# Altium schematic sheet Power_Indicator.SchDoc
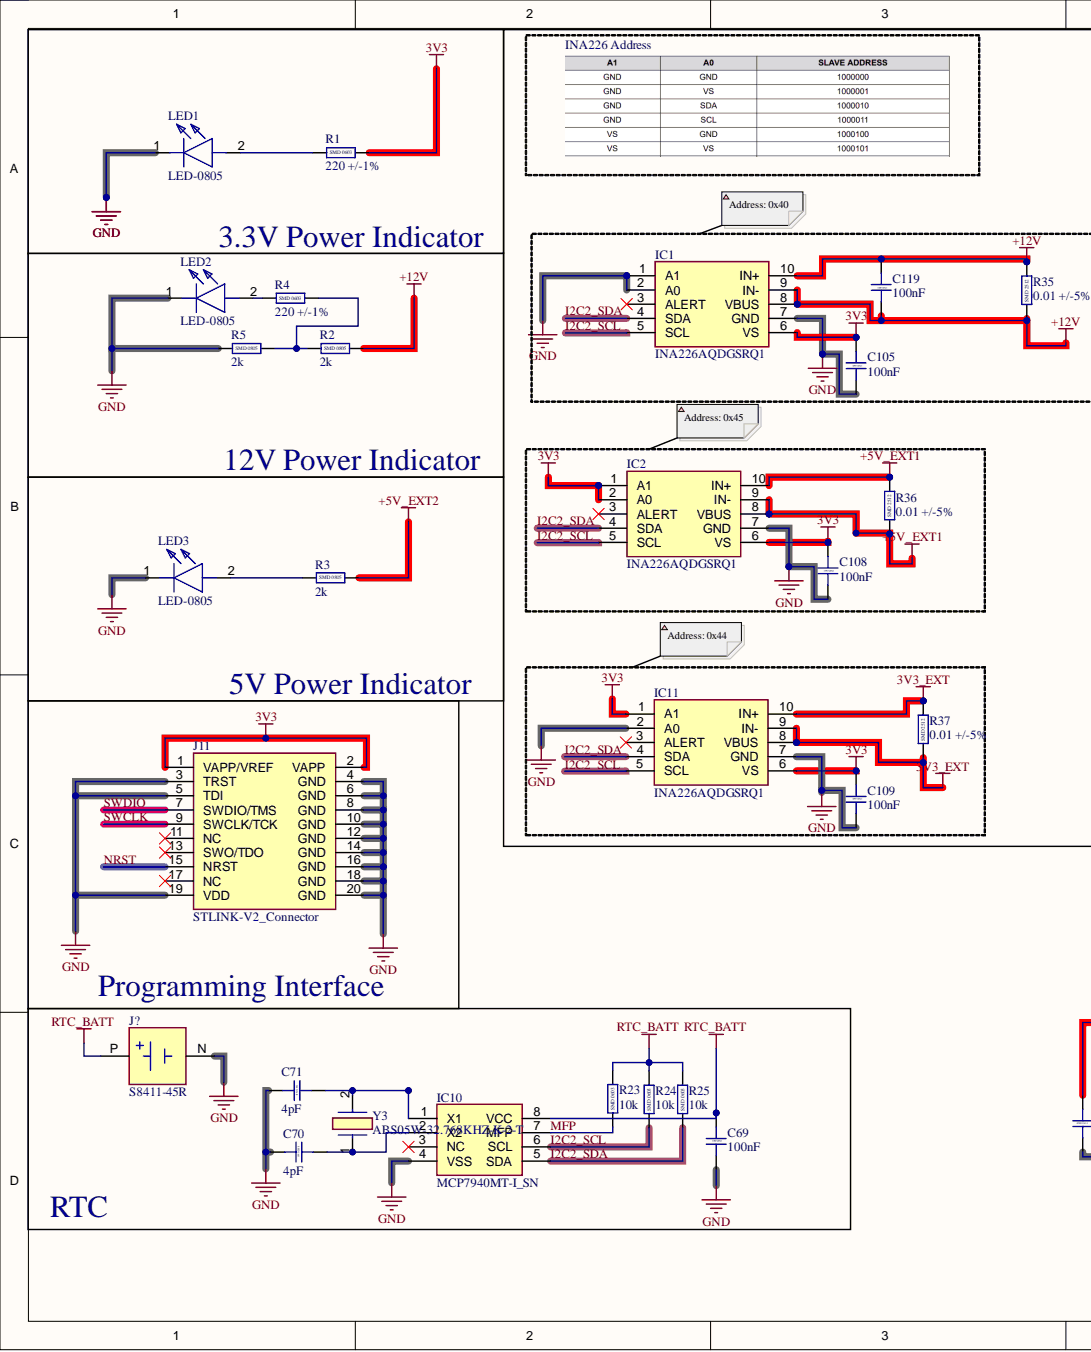
[diagram p0: Power_Indicator.SchDoc, left]
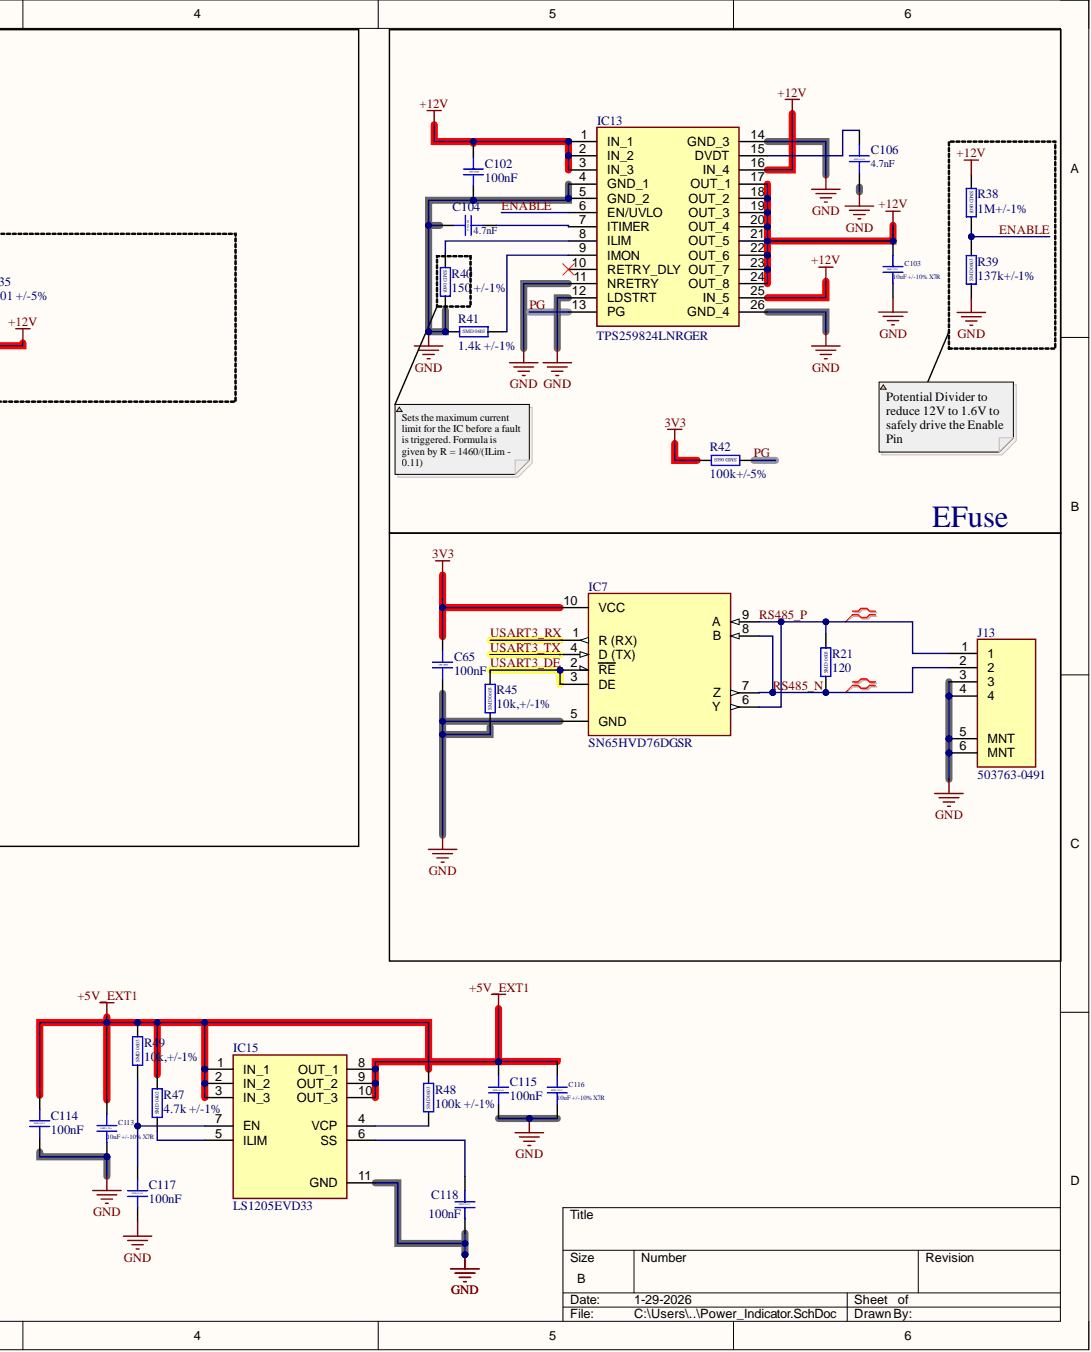
[diagram p1: Power_Indicator.SchDoc, right]

## Parts
C65  100nF  [CAP_0603]
C69  100nF  [CAP_0603]
C70  4pF  [CAP_0603]
C71  4pF  [CAP_0603]
C102  100nF  [CAP_0603]
C103  10uF +/-10% X7R  [CAP_1206]
C104  4.7nF  [CAP_0603]
C105  100nF  [CAP_0603]
C106  4.7nF  [CAP_0603]
C108  100nF  [CAP_0603]
C109  100nF  [CAP_0603]
C113  10uF +/-10% X7R  [CAP_1206]
C114  100nF  [CAP_0603]
C115  100nF  [CAP_0603]
C116  10uF +/-10% X7R  [CAP_1206]
C117  100nF  [CAP_0603]
C118  100nF  [CAP_0603]
C119  100nF  [CAP_0603]
IC1  INA226AQDGSRQ1  [SOP50P490X110-10N]
IC2  INA226AQDGSRQ1  [SOP50P490X110-10N]
IC7  SN65HVD76DGSR  [SOP50P490X110-10N]
IC10  MCP7940MT-I_SN  [SOIC127P600X175-8N]
IC11  INA226AQDGSRQ1  [SOP50P490X110-10N]
IC13  TPS259824LNRGER  [TPS259824LNRGER]
IC15  LS1205EVD33  [LS1205EVD33]
J11  STLINK-V2_Connector  [SHDR20W64P254_2X10_3272X859X991P]
J13  503763-0491  [5037630491]
J?  S8411-45R  [HARWIN_S8411-45R]
LED1  LED-0805  [LEDC3216X120N]
LED2  LED-0805  [LEDC3216X120N]
LED3  LED-0805  [LEDC3216X120N]
R1  220 +/-1%  [RES_0603]
R2  2k  [RES_0805]
R3  2k  [RES_0805]
R4  220 +/-1%  [RES_0603]
R5  2k  [RES_0805]
R21  120  [RES_0603]
R23  10k  [RES_0603]
R24  10k  [RES_0603]
R25  10k  [RES_0603]
R35  0.01 +/-5%  [RES_2512]
R36  0.01 +/-5%  [RES_2512]
R37  0.01 +/-5%  [RES_2512]
R38  1M+/-1%  [RES_0805]
R39  137k+/-1%  [RES_0603]
R40  150 +/-1%  [RES_0603]
R41  1.4k +/-1%  [RES_0603]
R42  100k+/-5%  [RES_0603]
R45  10k,+/-1%  [RES_0603]
R47  4.7k +/-1%  [RES_0402]
R48  100k +/-1%  [RES_0603]
R49  10k,+/-1%  [RES_0603]
Y3  ABS05W-32.768KHZ-K-2-T  [ABS05]

## Nets
3V3: C65.2 C105.2 C108.2 C109.2 IC1.6(VS) IC2.1(A1) IC2.2(A0) IC2.6(VS) IC7.10(VCC) IC11.1(A1) IC11.6(VS) J11.1(VAPP/VREF) J11.2(VAPP) R1.2 R42.2
3V3_EXT: IC11.8(VBUS) IC11.9(IN-) IC11.10(IN+) R37.1 R37.2
+5V_EXT1: C113.1 C114.1 C115.1 C116.1 IC2.8(VBUS) IC2.9(IN-) IC2.10(IN+) IC15.1(IN_1) IC15.2(IN_2) IC15.3(IN_3) IC15.8(OUT_1) IC15.9(OUT_2) IC15.10(OUT_3) R36.1 R36.2 R47.2 R48.2 R49.2
+5V_EXT2: R3.2
+12V: C102.2 C103.1 C119.1 C119.2 IC1.8(VBUS) IC1.9(IN-) IC1.10(IN+) IC13.1(IN_1) IC13.2(IN_2) IC13.3(IN_3) IC13.16(IN_4) IC13.17(OUT_1) IC13.18(OUT_2) IC13.19(OUT_3) IC13.20(OUT_4) IC13.21(OUT_5) IC13.22(OUT_6) IC13.23(OUT_7) IC13.24(OUT_8) IC13.25(IN_5) R2.2 R35.1 R35.2 R38.1
GND: C65.1 C69.1 C70.2 C71.2 C102.1 C103.2 C104.2 C105.1 C106.2 C108.1 C109.1 C113.2 C114.2 C115.2 C116.2 C117.2 C118.2 IC1.1(A1) IC1.2(A0) IC1.7(GND) IC2.7(GND) IC7.5(GND) IC10.4(VSS) IC11.2(A0) IC11.7(GND) IC13.4(GND_1) IC13.5(GND_2) IC13.11(NRETRY) IC13.12(LDSTRT) IC13.14(GND_3) IC13.26(GND_4) IC15.11(GND) J11.3(TRST) J11.4(GND) J11.5(TDI) J11.6(GND) J11.8(GND) J11.10(GND) J11.12(GND) J11.14(GND) J11.16(GND) J11.18(GND) J11.19(VDD) J11.20(GND) J13.3 J13.4 J13.5(MNT) J13.6(MNT) J?.N LED1.1 LED2.1 LED3.1 R5.1 R39.1 R40.2 R41.1 R45.1
RTC_BATT: C69.2 IC10.8(VCC) J?.P R23.2 R24.2 R25.2
ENABLE: IC13.6(EN/UVLO) R38.2 R39.2
I2C2_SCL: IC1.5(SCL) IC2.5(SCL) IC10.6(SCL) IC11.5(SCL) R24.1
I2C2_SDA: IC1.4(SDA) IC2.4(SDA) IC10.5(SDA) IC11.4(SDA) R25.1
MFP: IC10.7(MFP) R23.1
NRST: J11.15(NRST)
PG: IC13.13(PG) R42.1
RS485_N: IC7.7(Z) IC7.8(B) J13.2 R21.1
RS485_P: IC7.6(Y) IC7.9(A) J13.1 R21.2
SWCLK: J11.9(SWCLK/TCK)
SWDIO: J11.7(SWDIO/TMS)
USART3_DE: IC7.2(RE) IC7.3(DE) R45.2
USART3_RX: IC7.1(R_(RX))
USART3_TX: IC7.4(D_(TX))
NetC70_1: C70.1 IC10.2(X2) Y3.1
NetC71_1: C71.1 IC10.1(X1) Y3.2
NetC104_1: C104.1 IC13.7(ITIMER)
NetC106_1: C106.1 IC13.15(DVDT)
NetC117_1: C117.1 IC15.7(EN) R49.1
NetC118_1: C118.1 IC15.6(SS)
NetIC13_8: IC13.8(ILIM) R40.1
NetIC13_9: IC13.9(IMON) R41.2
NetIC15_4: IC15.4(VCP) R48.1
NetIC15_5: IC15.5(ILIM) R47.1
NetLED1_2: LED1.2 R1.1
NetLED2_2: LED2.2 R4.1
NetLED3_2: LED3.2 R3.1
NetR2_1: R2.1 R4.2 R5.2

Not connected: IC1 3(ALERT); IC2 3(ALERT); IC10 3(NC); IC11 3(ALERT); IC13 10(RETRY_DLY); J11 11(NC) 13(SWO/TDO) 17(NC)

## Library data of the parts
C65 C69 C102 C105 C108 C109 C114 C115 C117-C119 = Capacitor-SMD-0603: C0603C104K3RACTU; Component Kind: Standard; Library Name: STeRG-Passives-Standard.SchLib
C70 C71 = Capacitor-SMD-0603: CGA3E2NP02A040C080AA; Component Kind: Standard; Library Name: STeRG-Passives-Standard.SchLib
C103 C113 C116 = Capacitor-SMD-1206: Capacitor SMD 1206 RATED; Component Kind: Standard; Library Name: STeRG-Passives-Standard.SchLib
C104 C106 = Capacitor-SMD-0603: 885012206063; Component Kind: Standard; Library Name: STeRG-Passives-Standard.SchLib
IC1 IC2 IC11 = INA226AQDGSRQ1: Integrated Circuit; Manufacturer_Name: Texas Instruments; Arrow Part Number: INA226AQDGSRQ1; Arrow Price/Stock: https://www.arrow.com/en/products/ina226aqdgsrq1/texas-instruments?utm_currency=USD&region=nac; Component Kind: Standard; Datasheet Link: http://www.ti.com/lit/gpn/ina226-q1; Height: 1.1mm; Manufacturer_Part_Number: INA226AQDGSRQ1; Mouser Part Number: 595-INA226AQDGSRQ1; Mouser Price/Stock: https://www.mouser.co.uk/ProductDetail/Texas-Instruments/INA226AQDGSRQ1?qs=uNh9J9Y3wTf1B1%2FBFFp7SA%3D%3D
IC7 = SN65HVD76DGSR: RS485 Full Duplex Transciever; Manufacturer_Name: Texas Instruments; Arrow Part Number: SN65HVD76DGSR; Arrow Price/Stock: https://www.arrow.com/en/products/sn65hvd76dgsr/texas-instruments?region=nac; Component Kind: Standard; Datasheet Link: http://www.ti.com/lit/gpn/sn65hvd76; Height: 1.1mm; Manufacturer_Part_Number: SN65HVD76DGSR; Mouser Part Number: 595-SN65HVD76DGSR; Mouser Price/Stock: https://www.mouser.co.uk/ProductDetail/Texas-Instruments/SN65HVD76DGSR?qs=7z%252BmIopC6%2FI%252B8LLIzcebYw%3D%3D; Library Name: STeRG-ICs-Standard.SchLib
IC10 = MCP7940MT-I_SN: Integrated Circuit; Manufacturer_Name: Microchip; Manufacturer_Part_Number: MCP7940MT-I/SN; Arrow Part Number: MCP7940MT-I/SN; Arrow Price/Stock: https://www.arrow.com/en/products/mcp7940mt-isn/microchip-technology?utm_currency=USD&region=nac; Component Kind: Standard; Datasheet Link: http://ww1.microchip.com/downloads/en/DeviceDoc/20002292B.pdf; Height: 1.75mm; Mouser Part Number: 579-MCP7940MT-I/SN; Mouser Price/Stock: https://www.mouser.co.uk/ProductDetail/Microchip-Technology/MCP7940MT-I-SN?qs=V1AtWP4RhX63aO4jkgt0%2FA%3D%3D
IC13 = TPS259824LNRGER: Integrated Circuit; Manufacturer_Name: Texas Instruments; Arrow Part Number: TPS259824LNRGER; Arrow Price/Stock: https://www.arrow.com/en/products/tps259824lnrger/texas-instruments?region=nac; Component Kind: Standard; Datasheet Link: https://www.ti.com/lit/ds/symlink/tps25982.pdf?ts=1732181452429&ref_url=https%253A%252F%252Fwww.ti.com%252Fproduct%252FTPS25982%252Fpart-details%252FTPS259824LNRGER%253FkeyMatch%253DTPS259824LNRGER%2526tisearch%253Duniversal_search%2526usecase%253DOPN; Height: 1mm; Manufacturer_Part_Number: TPS259824LNRGER; Mouser Part Number: 595-TPS259824LNRGER; Mouser Price/Stock: https://www.mouser.co.uk/ProductDetail/Texas-Instruments/TPS259824LNRGER?qs=uwxL4vQweFP7OCB7glvbPw%3D%3D
IC15 = LS1205EVD33: EFuse IC; Manufacturer_Name: LITTELFUSE; Arrow Part Number: LS1205EVD33; Arrow Price/Stock: https://www.arrow.com/en/products/ls1205evd33/littelfuse?utm_currency=USD&region=nac; Component Kind: Standard; Datasheet Link: https://www.littelfuse.com/media?resourcetype=datasheets&itemid=b40a8809-c1ad-459d-a34c-84532dbddcb7&filename=littelfuse-protection-ic-ls1205exd33--datasheet; Height: 0.8mm; Manufacturer_Part_Number: LS1205EVD33; Mouser Part Number: 576-LS1205EVD33; Mouser Price/Stock: https://www.mouser.co.uk/ProductDetail/Littelfuse/LS1205EVD33?qs=4ASt3YYao0VRtislHe5uQQ%3D%3D; Library Name: STeRG-ICs-Standard.SchLib
J11 = STLINK-V2_Header: Connector; Manufacturer_Name: 3M; Manufacturer_Part_Number: N2520-6002-RB; Arrow Part Number: N2520-6002-RB; Arrow Price/Stock: https://www.arrow.com/en/products/n2520-6002-rb/3m?region=europe; Component Kind: Standard; Datasheet Link: http://multimedia.3m.com/mws/media/330367O/3m-four-wall-header-2500-series-ts-0770.pdf; Height: 9.91mm; Library Name: STeRG-Passives-Standard.SchLib
J13 = 503763-0491: Connector; Manufacturer_Name: Molex; Component Kind: Standard; Datasheet Link: https://www.molex.com/webdocs/datasheets/pdf/en-us/5037630491_PCB_HEADERS.pdf; Height: 1mm; Manufacturer_Part_Number: 503763-0491; Mouser Part Number: 538-503763-0491; Mouser Price/Stock: https://www.mouser.co.uk/ProductDetail/Molex/503763-0491?qs=zwvsCB%2Fxu0cTZVAek%2FYVrQ%3D%3D; Library Name: STeRG-Passives-Standard.SchLib
J? = S8411-45R: Coin Cell Battery Retainer, Horizontal SMT, for CR1220 Coin Cell Batteries, Tape & Reeled; MF: Harwin; Check_prices: https://www.snapeda.com/parts/S8411-45R/Harwin+Inc./view-part/?ref=eda; Component Kind: Standard; MP: S8411-45R; Package: None; SnapEDA_Link: https://www.snapeda.com/parts/S8411-45R/Harwin+Inc./view-part/?ref=snap; Library Name: STeRG-Passives-Standard.SchLib
LED1-LED3 = LED-0805: KPT-2012ZGC; Manufacturer_Name: Lite-On; Manufacturer_Part_Number: LTST-C230KFKT; Arrow Part Number: LTST-C230KFKT; Arrow Price/Stock: https://www.arrow.com/en/products/ltst-c230kfkt/lite-on-technology?region=nac; Component Kind: Standard; Datasheet Link: https://media.digikey.com/pdf/Data%20Sheets/Lite-On%20PDFs/LTST-C230KFKT_5-24-06.pdf; Height: 1.2mm; Mouser Part Number: 859-LTST-C230KFKT; Mouser Price/Stock: https://www.mouser.co.uk/ProductDetail/Lite-On/LTST-C230KFKT?qs=8myqPtD7QBPZHIbUaocfpw%3D%3D; Library Name: STeRG-Passives-Standard.SchLib
R1 R4 = Resistor-SMD-0603: CRCW0603220RFKEA; Component Kind: Standard; Library Name: STeRG-Passives-Standard.SchLib
R2 R3 R5 = Resistor-SMD-0805: ERA3AEB202V; Component Kind: Standard; Library Name: STeRG-Passives-Standard.SchLib
R21 = Resistor-SMD-0603: Resistor SMD 0603 RATED; Component Kind: Standard; Library Name: STeRG-Passives-Standard.SchLib
R23-R25 R45 R49 = Resistor-SMD-0603: CRCW060310K0FKEA; Component Kind: Standard; Library Name: STeRG-Passives-Standard.SchLib
R35-R37 = Resistor-SMD-2512: Resistor SMD 2512 RATED; Component Kind: Standard; Library Name: STeRG-Passives-Standard.SchLib
R38 = Resistor-SMD-0805: SFR10EZPF1004; Component Kind: Standard; Library Name: STeRG-Passives-Standard.SchLib
R39 R41 R42 = Resistor-SMD-0603: CRCW0603137KFKEA; Component Kind: Standard; Library Name: STeRG-Passives-Standard.SchLib
R40 = Resistor-SMD-0603: MCWR06X1500FTL; Component Kind: Standard; Library Name: STeRG-Passives-Standard.SchLib
R47 = Resistor-SMD-0402: MCWR04X4701FTL; Component Kind: Standard; Library Name: STeRG-Passives-Standard.SchLib
R48 = Resistor-SMD-0603: RC0603FR-13100KL; Component Kind: Standard; Library Name: STeRG-Passives-Standard.SchLib
Y3 = ABS05W-32.768KHZ-K-2-T: Crystal or Oscillator; Manufacturer_Name: ABRACON; Arrow Part Number: ABS05W-32.768KHZ-K-2-T; Arrow Price/Stock: https://www.arrow.com/en/products/abs05w-32.768khz-k-2-t/abracon?utm_currency=USD&region=europe; Component Kind: Standard; Datasheet Link: https://abracon.com/Resonators/ABS05W.pdf; Library Name: SamacSys.SchLib; Manufacturer_Part_Number: ABS05W-32.768KHZ-K-2-T; Mouser Part Number: 815-ABS05W32.768KK2T; Mouser Price/Stock: https://www.mouser.co.uk/ProductDetail/ABRACON/ABS05W-32.768KHZ-K-2-T?qs=r5DSvlrkXmJQzCoGJH4H9g%3D%3D
